# Altium schematic sheet SCH_Slave.SchDoc
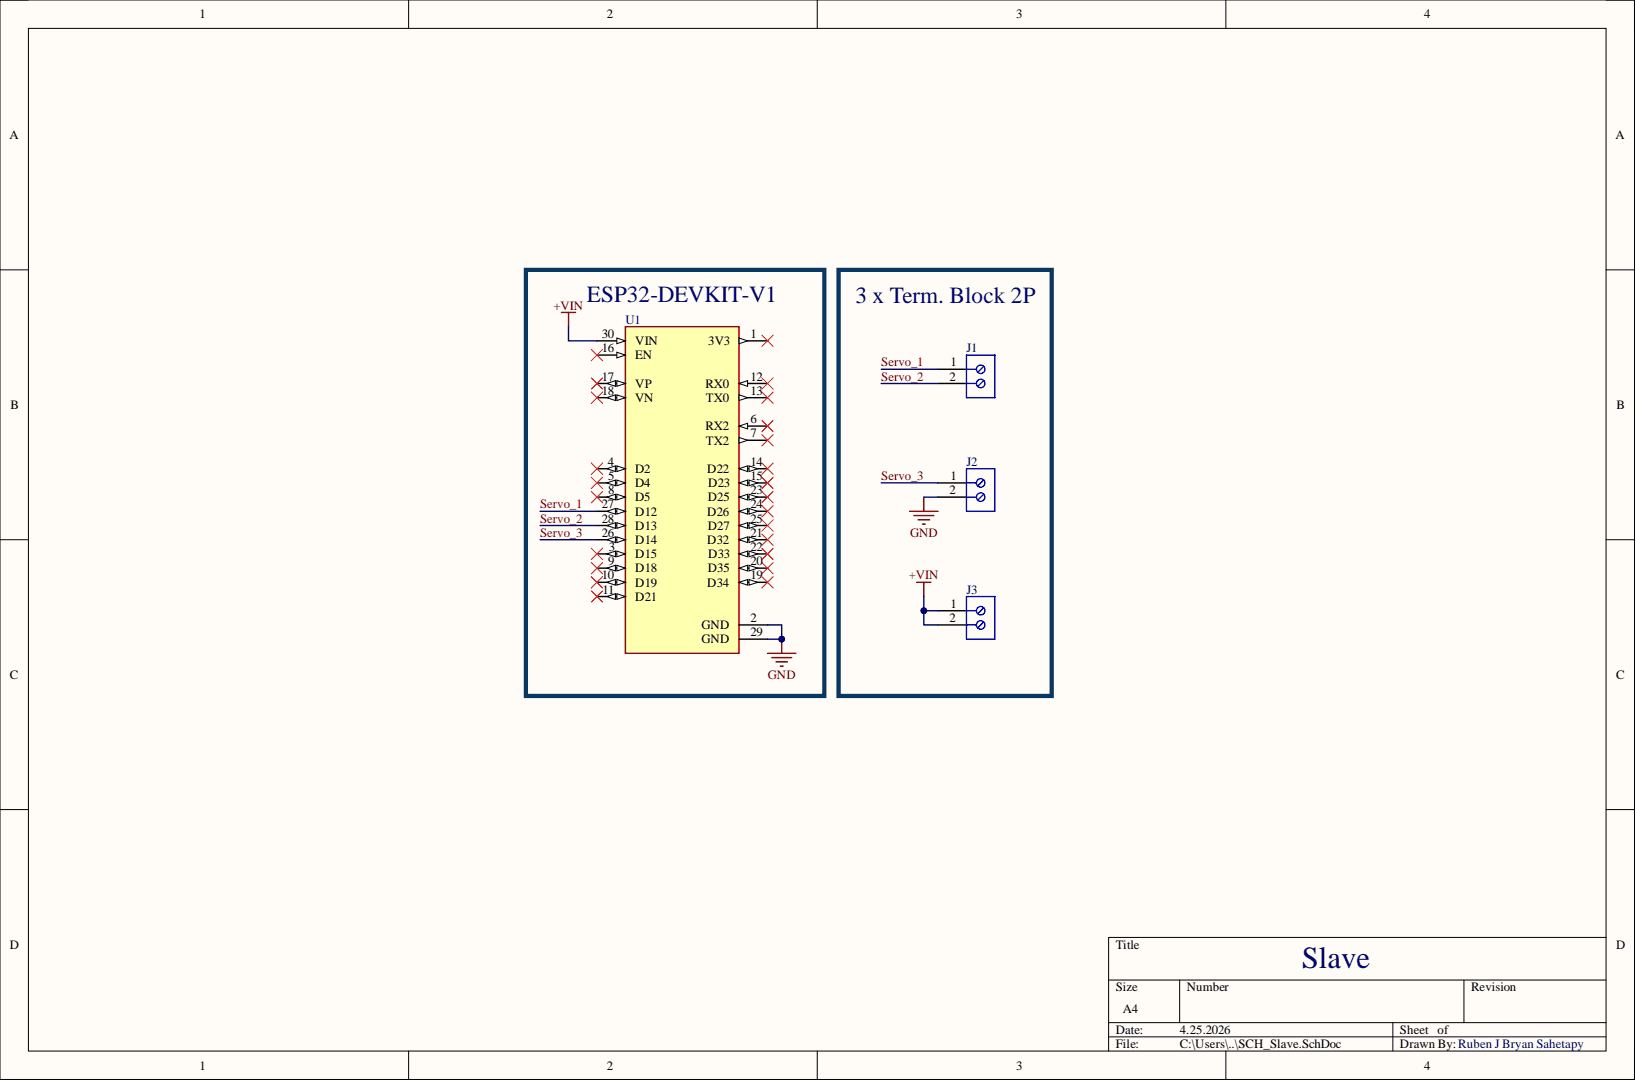
[diagram p0: SCH_Slave.SchDoc]

## Parts
J1  Term. Block 2P  [691253410002]
J2  Term. Block 2P  [691253410002]
J3  3 x Term. Block 2P  [691253410002]
U1  ESP32-DEVKIT-V1  [MODULE_ESP32_DEVKIT_V1]

## Nets
+VIN: J3.1 J3.2 U1.30(VIN)
GND: J2.2 U1.2(GND) U1.29(GND)
Servo_1: J1.1 U1.27(D12)
Servo_2: J1.2 U1.28(D13)
Servo_3: J2.1 U1.26(D14)

Not connected: U1 1(3V3) 3(D15) 4(D2) 5(D4) 6(RX2) 7(TX2) 8(D5) 9(D18) 10(D19) 11(D21) 12(RX0) 13(TX0) 14(D22) 15(D23) 16(EN) 17(VP) 18(VN) 19(D34) 20(D35) 21(D32) 22(D33) 23(D25) 24(D26) 25(D27)

## Library data of the parts
J1-J3 = CMP-1502-02746-3: Terminal Block, 7.62MM Pitch, Horizontal, Rising Cage Clamp, 12-30 AWG, 2 Pin; Component Kind: Standard; Library Name: Altium Content Vault
U1 = ESP32-DEVKIT-V1: ESP32-DEVKIT-V1 30 Pins; Database Table Name: Components$; MF: Do it; Value: Dual Core, Wi-Fi, BLE; Check_prices: https://www.snapeda.com/parts/ESP32-DEVKIT-V1/Do+it/view-part/?ref=eda; Component Kind: Standard; Link: https://www.snapeda.com/parts/ESP32-DEVKIT-V1/Do+it/view-part/?ref=eda; MP: ESP32-DEVKIT-V1; Package: None; Part Number: ESP32-DEVKIT-V1; PartID: ESP32-DEVKIT-V1; SnapEDA_Link: https://www.snapeda.com/parts/ESP32-DEVKIT-V1/Do+it/view-part/?ref=snap; TempMax: 85; TempMin: -40; Library Name: MarbleLib.DbLib
